# Altium schematic sheet 06 M2_M_KEY.SchDoc
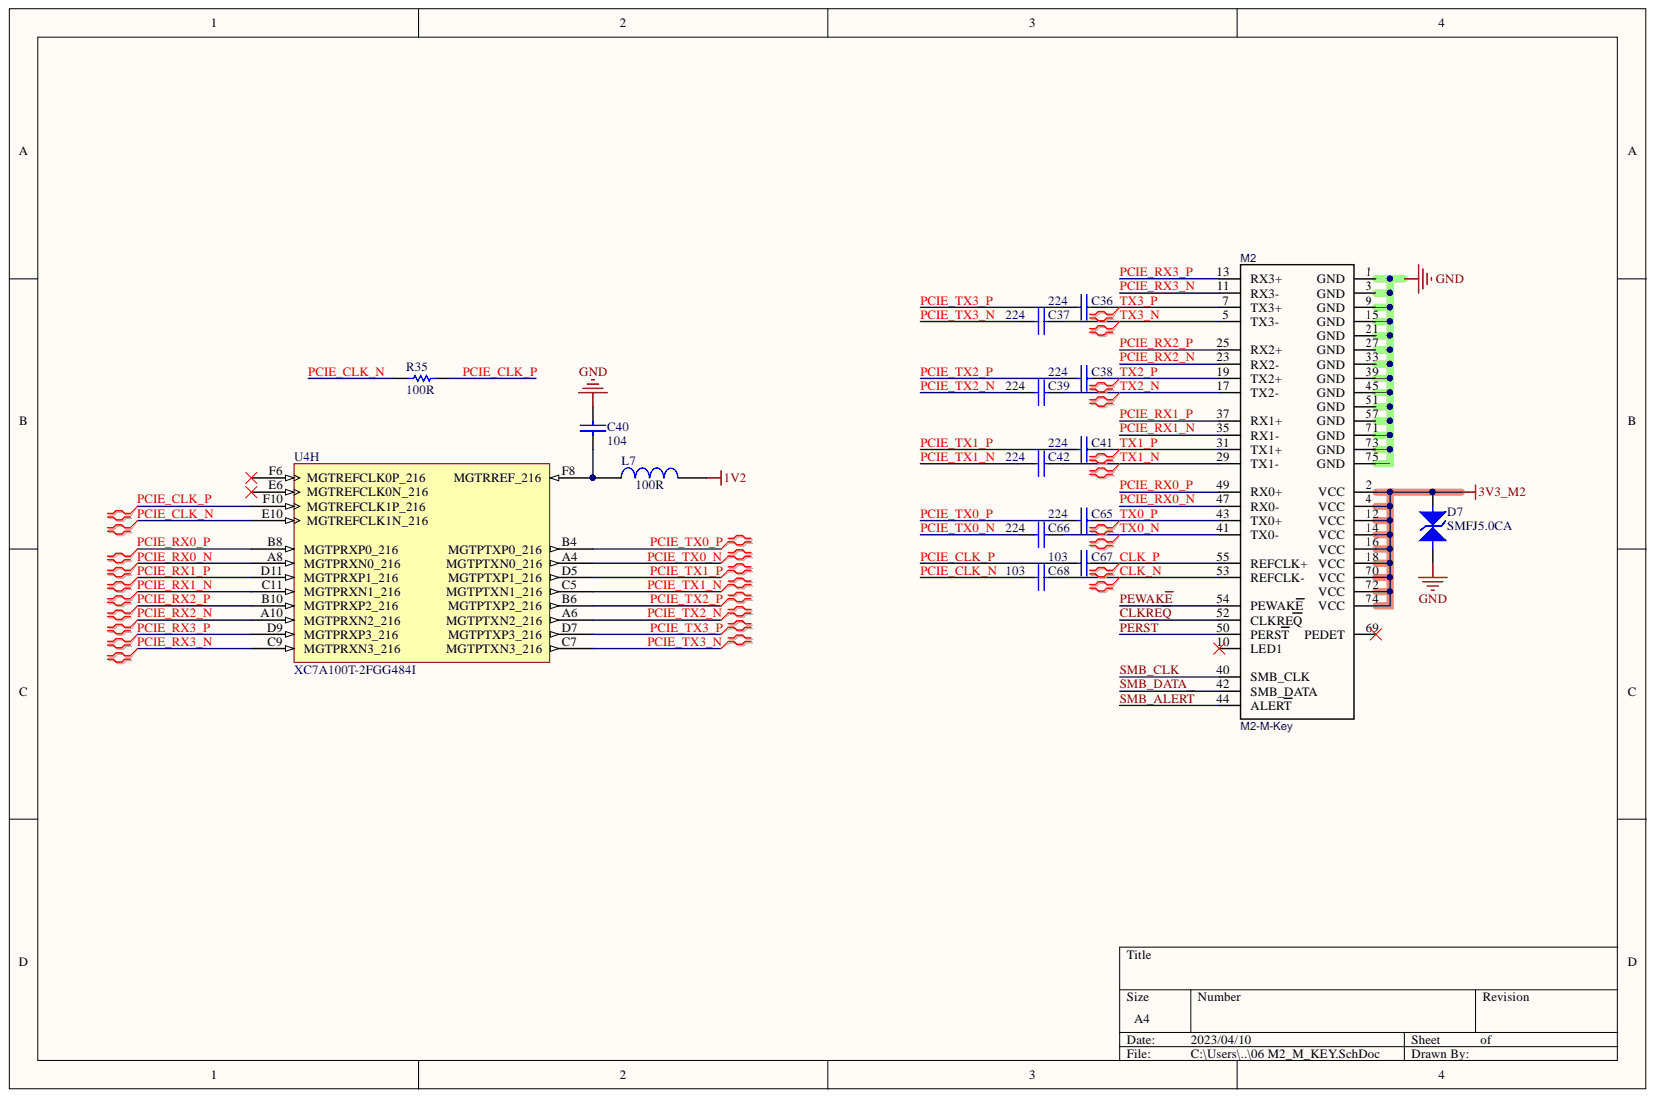
[diagram p0: 06 M2_M_KEY.SchDoc]

## Parts
C36  224  [0402C]
C37  224  [0402C]
C38  224  [0402C]
C39  224  [0402C]
C40  104  [0402C]
C41  224  [0402C]
C42  224  [0402C]
C65  224  [0402C]
C66  224  [0402C]
C67  103  [0402C]
C68  103  [0402C]
D7  SMFJ5.0CA  [SOD-123]
L7  100R  [0603L]
M2  M2-M-Key  [M.2_NGFF]
R35  100R  [0402R]
U4  XC7A100T-2FGG484I  [FGG484]  (part H)

## Nets
1V2: L7.2
3V3_M2: D7.A M2.2(VCC) M2.4(VCC) M2.12(VCC) M2.14(VCC) M2.16(VCC) M2.18(VCC) M2.70(VCC) M2.72(VCC) M2.74(VCC)
GND: C40.1 D7.K M2.1(GND) M2.3(GND) M2.9(GND) M2.15(GND) M2.21(GND) M2.27(GND) M2.33(GND) M2.39(GND) M2.45(GND) M2.51(GND) M2.57(GND) M2.71(GND) M2.73(GND) M2.75(GND)
CLK_N: C68.2 M2.53(REFCLK-)
CLK_P: C67.2 M2.55(REFCLK+)
CLKRE~{Q}: M2.52(CLKREQ)
PCIE_CLK_N: C68.1 R35.2 U4.E10(MGTREFCLK1N_216)
PCIE_CLK_P: C67.1 R35.1 U4.F10(MGTREFCLK1P_216)
PCIE_RX0_N: M2.47(RX0-) U4.A8(MGTPRXN0_216)
PCIE_RX0_P: M2.49(RX0+) U4.B8(MGTPRXP0_216)
PCIE_RX1_N: M2.35(RX1-) U4.C11(MGTPRXN1_216)
PCIE_RX1_P: M2.37(RX1+) U4.D11(MGTPRXP1_216)
PCIE_RX2_N: M2.23(RX2-) U4.A10(MGTPRXN2_216)
PCIE_RX2_P: M2.25(RX2+) U4.B10(MGTPRXP2_216)
PCIE_RX3_N: M2.11(RX3-) U4.C9(MGTPRXN3_216)
PCIE_RX3_P: M2.13(RX3+) U4.D9(MGTPRXP3_216)
PCIE_TX0_N: C66.1 U4.A4(MGTPTXN0_216)
PCIE_TX0_P: C65.1 U4.B4(MGTPTXP0_216)
PCIE_TX1_N: C42.1 U4.C5(MGTPTXN1_216)
PCIE_TX1_P: C41.1 U4.D5(MGTPTXP1_216)
PCIE_TX2_N: C39.1 U4.A6(MGTPTXN2_216)
PCIE_TX2_P: C38.1 U4.B6(MGTPTXP2_216)
PCIE_TX3_N: C37.1 U4.C7(MGTPTXN3_216)
PCIE_TX3_P: C36.1 U4.D7(MGTPTXP3_216)
PERS~{T}: M2.50(PERST)
PEWAK~{E}: M2.54(PEWAKE)
SMB_ALER~{T}: M2.44(ALERT)
SMB_CLK: M2.40(SMB_CLK)
SMB_DATA: M2.42(SMB_DATA)
TX0_N: C66.2 M2.41(TX0-)
TX0_P: C65.2 M2.43(TX0+)
TX1_N: C42.2 M2.29(TX1-)
TX1_P: C41.2 M2.31(TX1+)
TX2_N: C39.2 M2.17(TX2-)
TX2_P: C38.2 M2.19(TX2+)
TX3_N: C37.2 M2.5(TX3-)
TX3_P: C36.2 M2.7(TX3+)
NetC40_2: C40.2 L7.1 U4.F8(MGTRREF_216)

Not connected: M2 10(LED1) 69(PEDET); U4 E6(MGTREFCLK0N_216) F6(MGTREFCLK0P_216)

## Library data of the parts
C36-C42 C65-C68 = CAP: 无极性电容; Component Kind: Standard; Library Name: 电容.IntLib
D7 = TVS: 双向瞬态抑制二极管; Component Kind: Standard; Library Name: 二极管.IntLib
L7 = INDUCTOR: Component Kind: Standard; Description: INDUCTOR; Library Name: 电感.IntLib
M2 = M2-M-Key: Contributor: zengjf; Component Kind: Standard; Library Name: 未分类IC.IntLib; spicePre: U; spiceSymbolName: M2-M-Key
R35 = RES: Component Kind: Standard; Library Name: 电阻.IntLib
U4 = XC7A100T-2FGG484I: Artix-7 FPGA, 285 User I/Os, 4 GTP, 484-Ball BGA, Speed Grade 2, Industrial Grade, Pb-Free; Manufacturer: Xilinx; Block RAM Blocks (18Kb): 270; Block RAM Blocks (36Kb): 135; Block RAM Blocks (Max Kb): 4860; CLBs (Slices): 15850; Clock Mgmt Tiles (CMTs): 6; DSP48E1 Slices: 240; Logic Cells: 101440; Max User I/O: 300; Speed Grade: -2; CLBs (Max Distributed RAM Kb): 1188; Code_JEDEC: MS-034-AAJ-1; Component Kind: Standard; ComponentLink1Description: Manufacturer URL; ComponentLink1URL: http://www.xilinx.com/; ComponentLink2Description: Datasheet; ComponentLink2URL: http://www.xilinx.com/support/documentation/data_sheets/ds180_7Series_Overview.pdf; ComponentLink3Description: Package Specification; ComponentLink3URL: http://www.xilinx.com/support/documentation/user_guides/ug475_7Series_Pkg_Pinout.pdf; ComponentLink4Description: DC and Switching Characteristics; ComponentLink4URL: http://www.xilinx.com/support/documentation/data_sheets/ds181_Artix_7_Data_Sheet.pdf; ComponentLink5Description: Package File; ComponentLink5URL: http://www.xilinx.com/support/packagefiles/a7packages/xc7a100tfgg484pkg.txt; ComponentLink6Description: Packaging and Pinout; ComponentLink6URL: http://www.xilinx.com/support/documentation/user_guides/ug475_7Series_Pkg_Pinout.pdf; DatasheetVersion: v1.12; Generic Part Number: XC7A100T; Library Name: Xilinx Artix-7.IntLib; Mounting Technology: Surface Mount; NexusDeviceID: XC7A100T-2FGG484I; PackageDescription: Wire-Bond Fine-Pitch BGA, Body 23 x 23 mm, 1.0 mm Pitch; PackageReference: FGG484; PackageVersion: Rev 1.8; PartNumber: XC7A100T-2FGG484I; RoHS: Pb-Free; Supplier 1: Digi-Key; Supplier Part Number 1: 122-1879-ND
